# Altium schematic sheet current_measurment.SchDoc
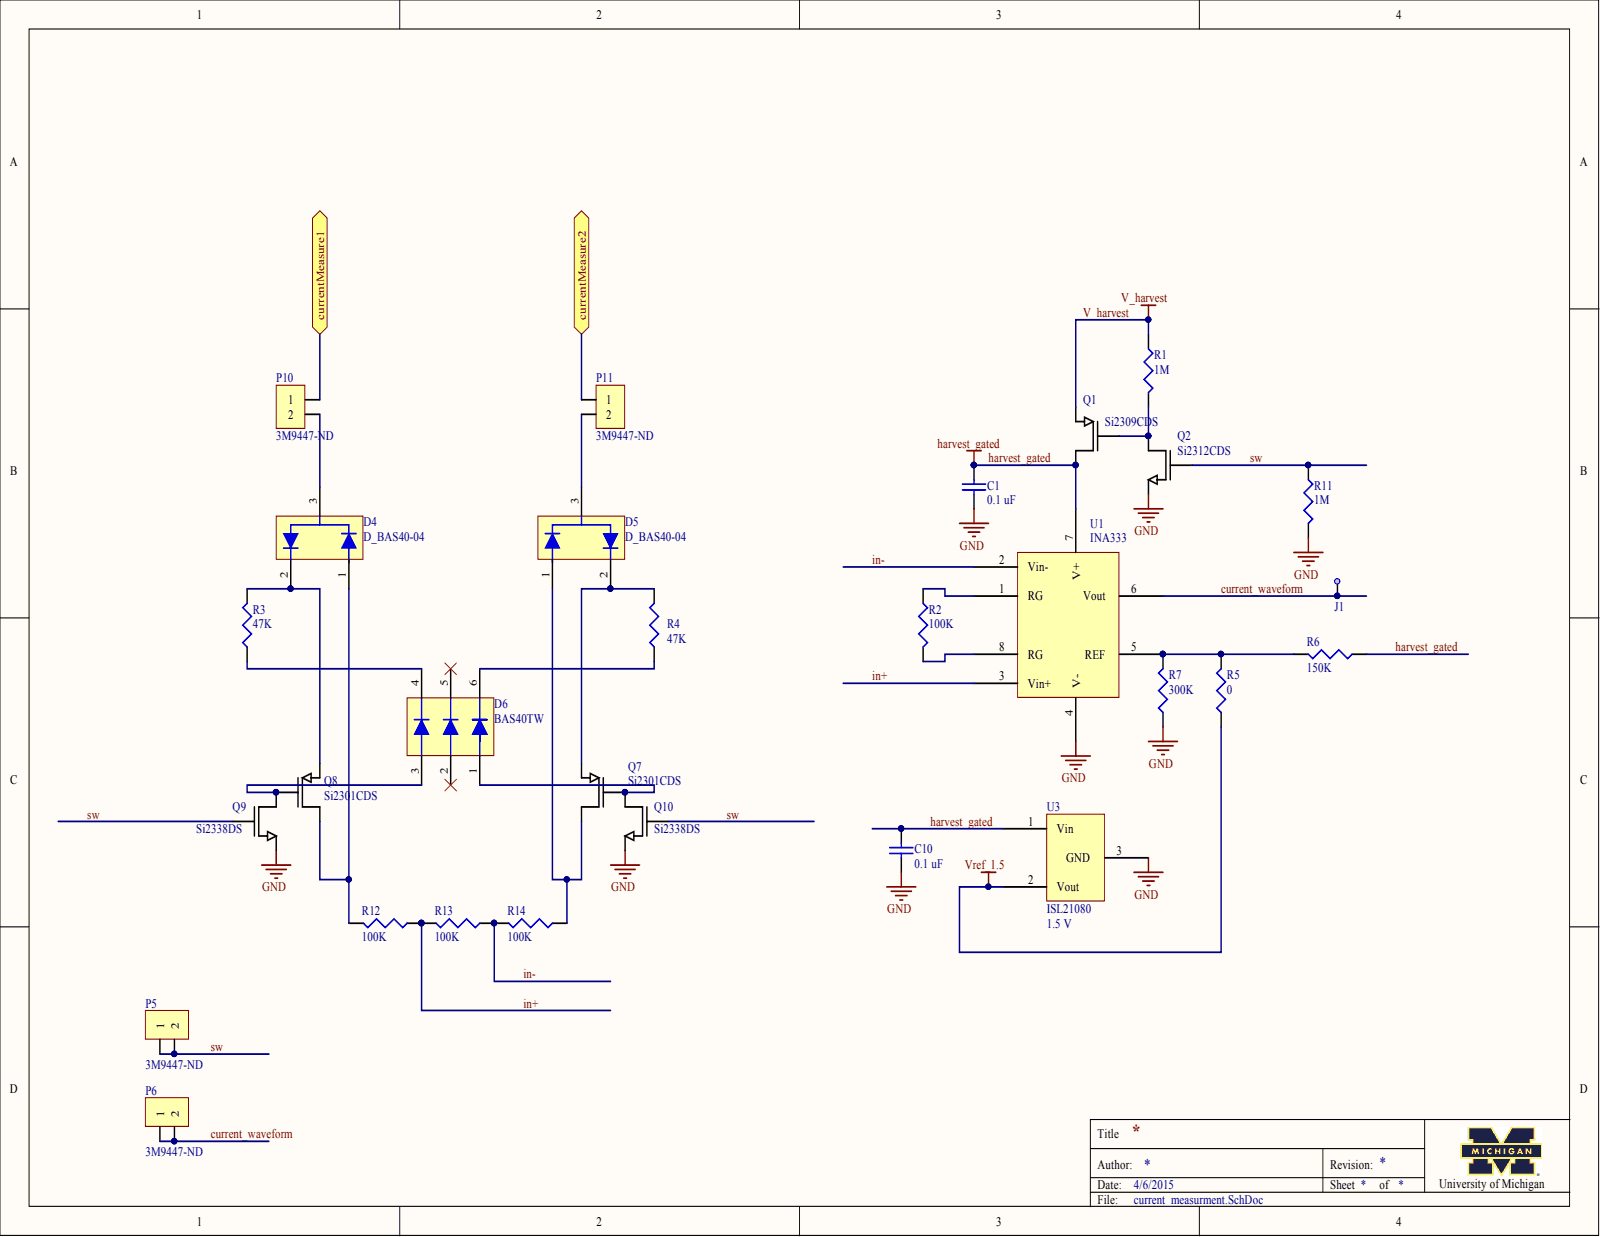
[diagram p0: current_measurment.SchDoc]

## Parts
C1  value 0.1 uF  [0603]  (hidden comment: Ceramic capacitor)
C10  value 0.1 uF  [0603]  (hidden comment: Ceramic capacitor)
D4  D_BAS40-04  [SOT23-3]
D5  D_BAS40-04  [SOT23-3]
D6  BAS40TW  [SOT-363]
J1  5004  [TestPoint]  (value not drawn)
P5  Supplier Part Number 1: 3M9447-ND  [1x2 header]  (hidden comment: 1 x 2 header)
P6  Supplier Part Number 1: 3M9447-ND  [1x2 header]  (hidden comment: 1 x 2 header)
P10  Supplier Part Number 1: 3M9447-ND  [1x2 header]  (hidden comment: 1 x 2 header)
P11  Supplier Part Number 1: 3M9447-ND  [1x2 header]  (hidden comment: 1 x 2 header)
Q1  Si2309CDS  [SOT23-3]
Q2  Si2312CDS  [SOT23-3]
Q7  Si2301CDS  [SOT23-3]
Q8  Si2301CDS  [SOT23-3]
Q9  Si2338DS  [SOT23-3]
Q10  Si2338DS  [SOT23-3]
R1  value 1M  [0603]  (hidden comment: Chip resistor)
R2  value 100K  [0603]  (hidden comment: Chip resistor)
R3  value 47K  [0603]  (hidden comment: Chip resistor)
R4  value 47K  [0603]  (hidden comment: Chip resistor)
R5  value 0  [0603]  (hidden comment: Chip resistor)
R6  value 150K  [0603]  (hidden comment: Chip resistor)
R7  value 300K  [0603]  (hidden comment: Chip resistor)
R11  value 1M  [0603]  (hidden comment: Chip resistor)
R12  value 100K  [0603]  (hidden comment: Chip resistor)
R13  value 100K  [0603]  (hidden comment: Chip resistor)
R14  value 100K  [0603]  (hidden comment: Chip resistor)
U1  INA333  [MSOP-8]
U3  ISL21080  Output Voltage: 1.5 V  [SOT23-3]

## Ports
CURRENTMEASURE1, CURRENTMEASURE2

## Nets
GND: C1.2 C10.2 Q2.2 Q9.2 Q10.2 R7.2 R11.1 U1.4(V-) U3.3
VREF_1.5: R5.2 U3.2
CURRENT_WAVEFORM: J1.1 P6.1 P6.2 U1.6(Vout)
HARVEST_GATED: C1.1 C10.1 Q1.3 R6.2 U1.7(V+) U3.1
IN+: R12.2 R13.1 U1.3(Vin+)
IN-: R13.2 R14.1 U1.2(Vin-)
SW: P5.1 P5.2 Q2.1 Q9.1 Q10.1 R11.2
V_HARVEST: Q1.2 R1.2
NetD4_1: D4.1 Q8.3 R12.1
NetD4_2: D4.2 Q8.2 R3.1
NetD4_3: D4.3 P10.2
NetD5_1: D5.1 Q7.3 R14.2
NetD5_2: D5.2 Q7.2 R4.1
NetD5_3: D5.3 P11.2
NetD6_1: D6.1 Q7.1 Q10.3
NetD6_3: D6.3 Q8.1 Q9.3
NetD6_4: D6.4 R3.2
NetD6_6: D6.6 R4.2
NetP10_1: P10.1 ; port CURRENTMEASURE1
NetP11_1: P11.1 ; port CURRENTMEASURE2
NetQ1_1: Q1.1 Q2.3 R1.1
NetR2_1: R2.1 U1.8(RG)
NetR2_2: R2.2 U1.1(RG)
NetR5_1: R5.1 R6.1 R7.1 U1.5(REF)

Not connected: D6 2 5

## Library data of the parts
C1 = C_0.1u_25V_0603_ceramic: Ceramic capacitor, 0.1 uF, 0603 package; Manufacture: TDK; tolerance: 10%; Value: 0.1 uF; voltage rated: 25V; Component Kind: Standard; Library Name: rlc.SchLib; package: 0603; Supplier 1: Digi-Key; Supplier Part Number 1: 445-1316-1-ND
C10 = C_0.1u_25V_0603_ceramic: Ceramic capacitor, 0.1 uF, 0603 package; Manufacture: TDK; tolerance: 10%; voltage rated: 25V; Component Kind: Standard; Library Name: rlc.SchLib; package: 0603; Supplier 1: Digi-Key; Supplier Part Number 1: 445-1316-1-ND
D4 D5 = D_BAS40-04: SURFACE MOUNT SCHOTTKY BARRIER DIODE; Component Kind: Standard; Library Name: rlc.SchLib; Supplier 1: Digi-Key; Supplier Part Number 1: BAS40-04FDICT-ND
D6 = D_BAS40TW: SURFACE MOUNT SCHOTTKY BARRIER DIODE ARRAYS; Component Kind: Standard; Library Name: rlc.SchLib; Supplier 1: Digi-Key; Supplier Part Number 1: BAS40TWDICT-ND
J1 = TestPoint: TEST POINT PC MINI .040"D YELLOW; Component Kind: Standard; datasheet: http://mail.keyelco.com/ENG._DEPT/PDF%20CAT%20NO%20Drawings/5004.pdf; Supplier 1: Digi-Key; Supplier Part Number 1: 5004K-ND; Library Name: coilcube_frontend.SchLib
P5 P6 P10 P11 = 1 x 2 header: 100 mils standard header; Component Kind: Standard; Library Name: connectors.SchLib; Supplier 1: Digi-Key
Q1 = Si2309CDS: P-Channel 60-V (D-S) MOSFET; Component Kind: Standard; Library Name: transistors.SchLib; Supplier 1: Digi-Key; Supplier Part Number 1: SI2309CDS-T1-E3CT-ND
Q2 = Si2312CDS: N-Channel 20 V (D-S) MOSFET; Component Kind: Standard; Library Name: transistors.SchLib; Supplier 1: Digi-Key; Supplier Part Number 1: SI2312CDS-T1-GE3CT-ND
Q7 Q8 = Si2301CDS: P-Channel 20 V (D-S) MOSFET; Component Kind: Standard; Library Name: transistors.SchLib; Supplier 1: Digi-Key; Supplier Part Number 1: SI2301CDS-T1-E3CT-ND
Q9 Q10 = Si2338DS: N-Channel 30 V (D-S) MOSFET; Component Kind: Standard; Library Name: transistors.SchLib; Supplier 1: Digi-Key; Supplier Part Number 1: SI2338DS-T1-GE3CT-ND
R1 R11 = R_1M_0603: Chip resistor, 1 Meg, 0603 package; power: 1/10 W; tolerance: 1%; Component Kind: Standard; Library Name: rlc.SchLib; package: 0603; Supplier 1: Digi-Key; Supplier Part Number 1: 541-1.00MHCT-ND
R2 R12-R14 = R_100K_0603: Chip resistor, 100K, 0603 package; power: 1/10 W; tolerance: 1%; Component Kind: Standard; Library Name: rlc.SchLib; package: 0603; Supplier 1: Digi-Key; Supplier Part Number 1: 541-100KHCT-ND
R3 R4 = R_47K_0603: Chip resistor, 47K, 0603 package; power: 1/10 W; tolerance: 1%; Component Kind: Standard; Library Name: rlc.SchLib; package: 0603; Supplier 1: Digi-Key; Supplier Part Number 1: 541-47.0KHCT-ND
R5 = R_0_0603: Chip resistor, 0, 0603 package; Component Kind: Standard; Library Name: rlc.SchLib; package: 0603; Supplier 1: Digi-Key; Supplier Part Number 1: 541-0.0GCT-ND
R6 = R_150K_0603: Chip resistor, 150K, 0603 package; power: 1/10 W; tolerance: 1%; Component Kind: Standard; Library Name: rlc.SchLib; package: 0603; Supplier 1: Digi-Key; Supplier Part Number 1: 541-150KHCT-ND
R7 = R_300K_0603: Chip resistor, 300K, 0603 package; power: 1/10 W; tolerance: 1%; Component Kind: Standard; Library Name: rlc.SchLib; package: 0603; Supplier 1: Digi-Key; Supplier Part Number 1: 541-300KHCT-ND
U1 = INA333: Micro-Power (50µA), Zerø-Drift, Rail-to-Rail Out  Instrumentation Amplifier; Component Kind: Standard; Library Name: amplifier.SchLib; Supplier 1: Digi-Key; Supplier Part Number 1: 296-23564-1-ND
U3 = ISL21080: 300nA NanoPower Voltage References; Component Kind: Standard; Library Name: reference.SchLib; Supplier 1: Digi-Key; Supplier Part Number 1: ISL21080CIH315Z-T7ACT-ND
